# Altium schematic sheet MINIECO-ECO-ALM.SchDoc(MINIECO-ECO-ALM)
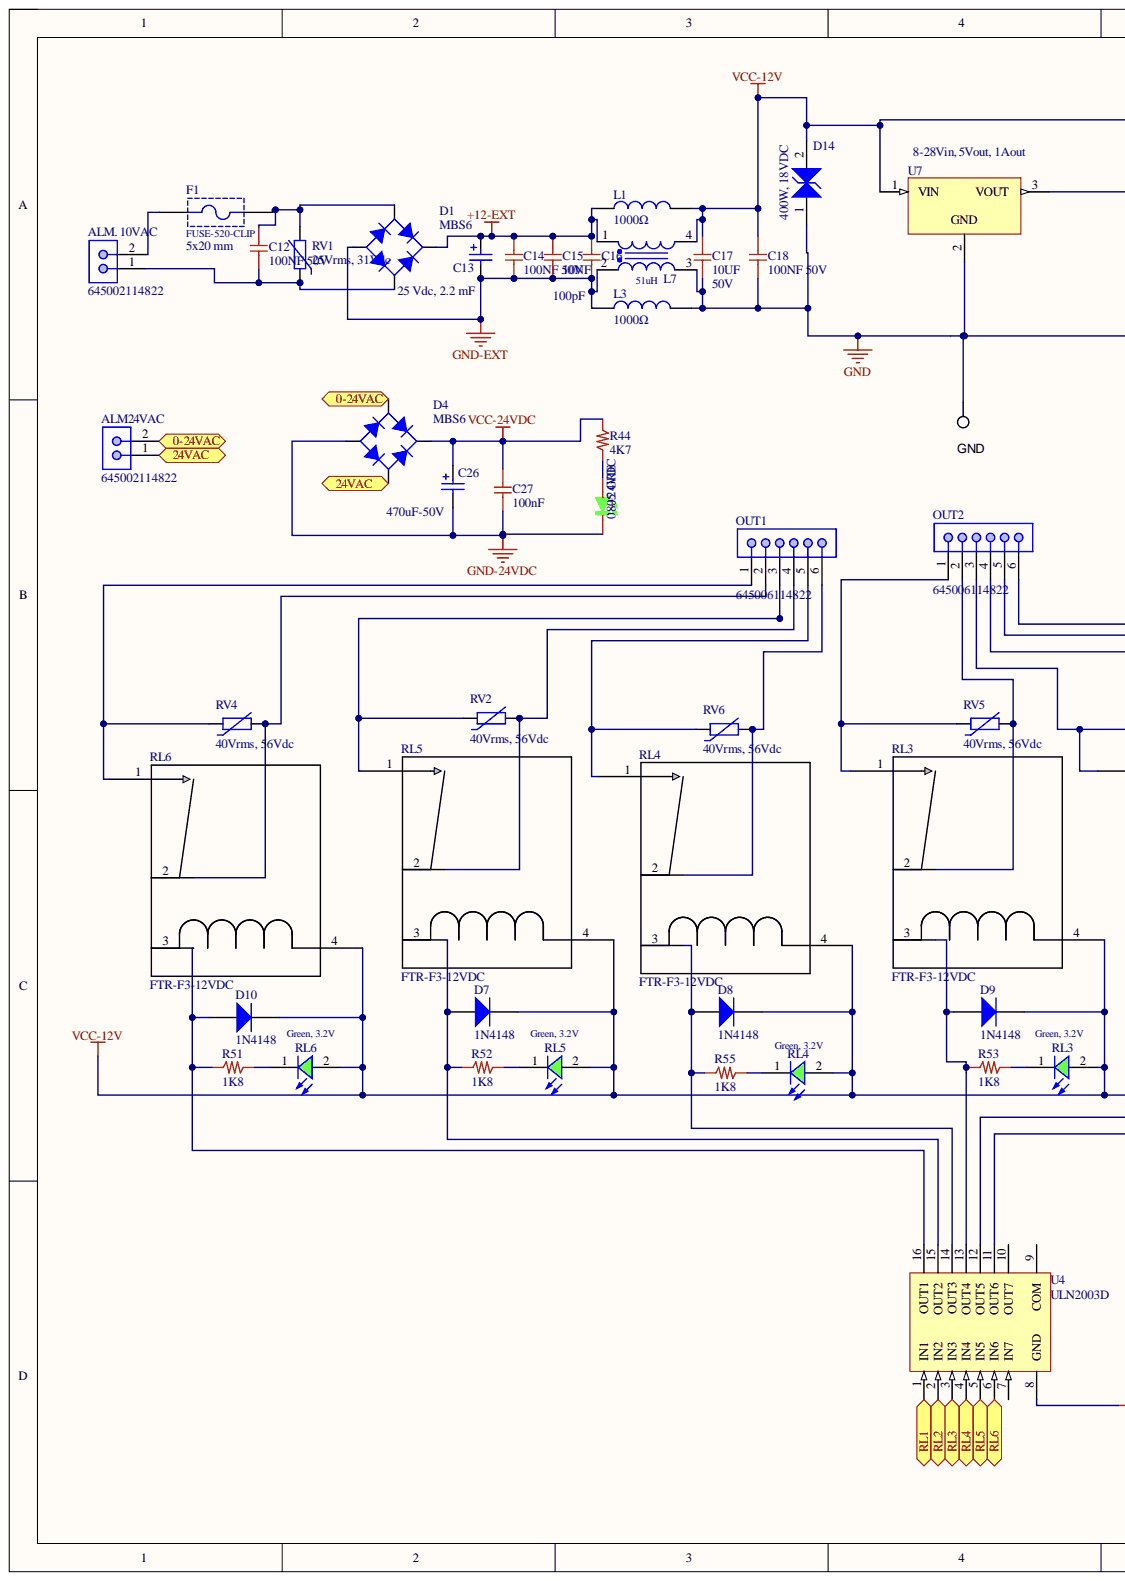
[diagram p0: MINIECO-ECO-ALM.SchDoc, left]
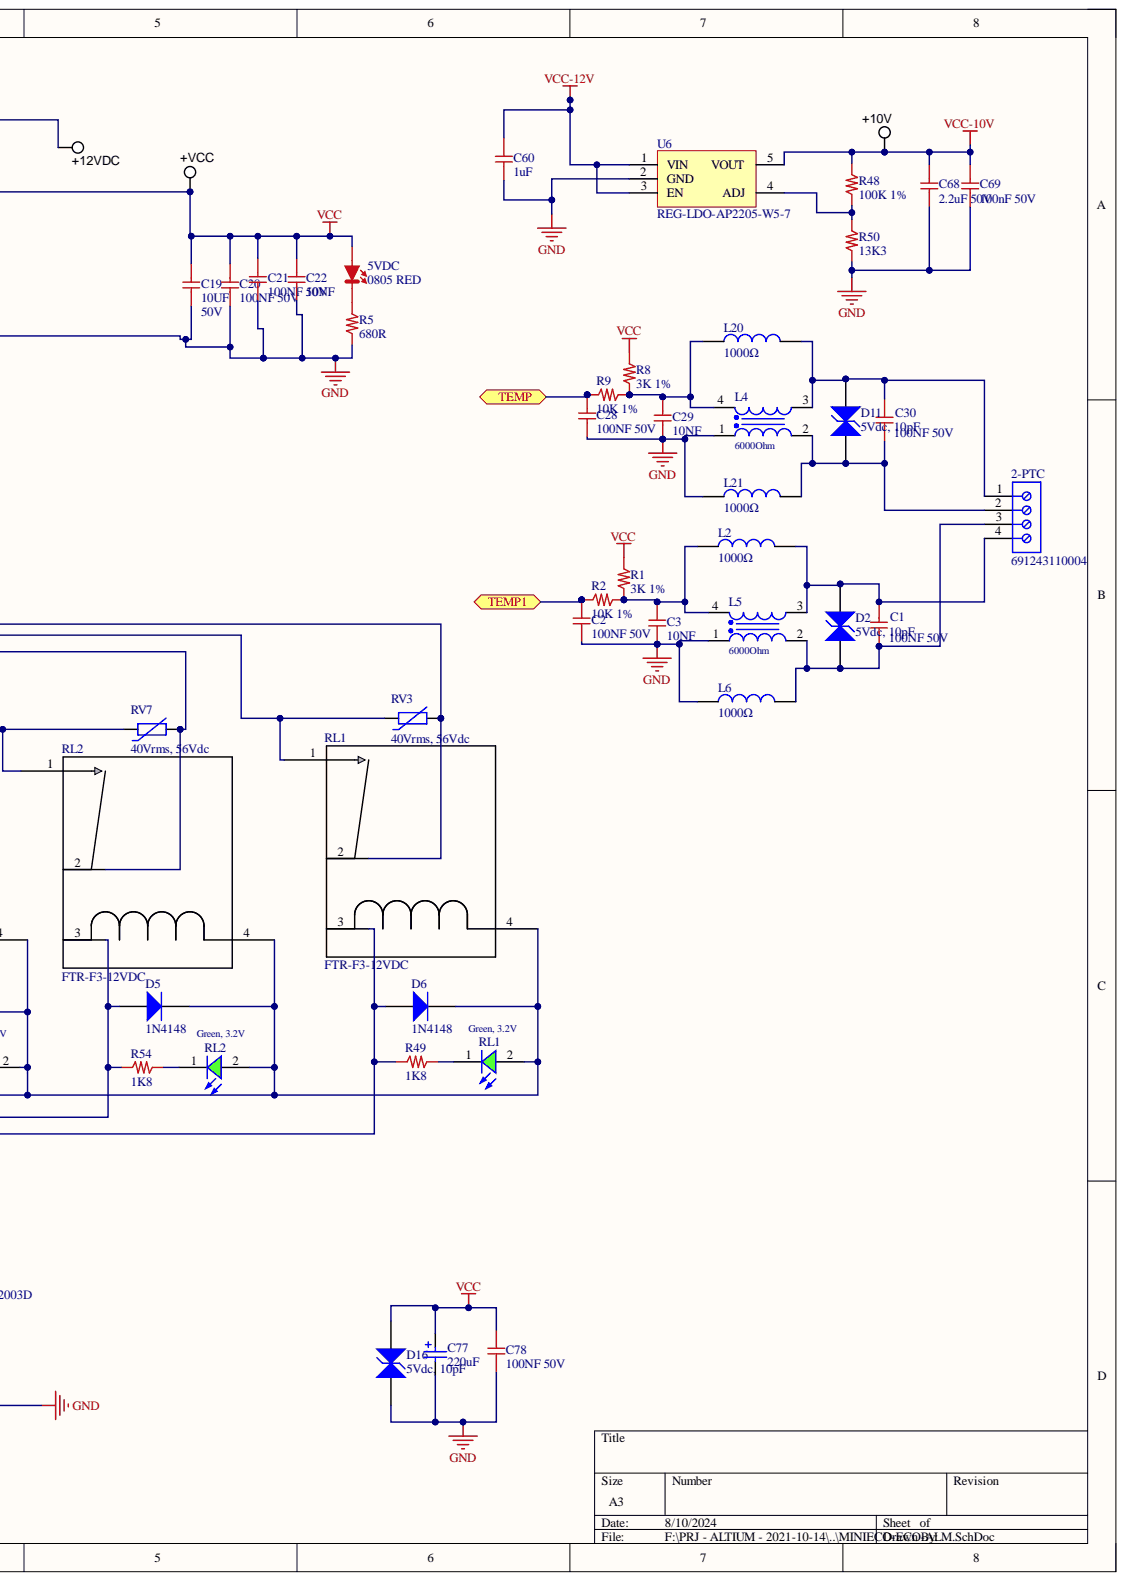
[diagram p1: MINIECO-ECO-ALM.SchDoc, right]

## Parts
2-PTC  691243110004  [691243110004]
5VDC  0805 RED  [0805_Red]
+10V  TEST POINT  [Keystone5012]  (value not drawn)
+12VDC  TEST POINT  [Keystone5012]  (value not drawn)
+24VDC  0805 GRE  [0805_Green]
+VCC  TEST POINT  [Keystone5012]  (value not drawn)
ALM24VAC  645002114822  [645002114822]
ALM._10VAC  645002114822  [645002114822]
C1  100NF 50V  [0603_c]
C2  100NF 50V  [0603_c]
C3  10NF  [0603_c]
C12  100NF 50V  [0603_c]
C13  25 Vdc, 2.2 mF  value 2.2 mF  [WCAP-ATLI_13x35x5]
C14  100NF 50V  [0603_c]
C15  10NF  [0603_c]
C16  100pF  [0603_c]
C17  10UF  Tensione: 50V  [1206_C]
C18  100NF 50V  [0603_c]
C19  10UF  Tensione: 50V  [1206_C]
C20  100NF 50V  [0603_c]
C21  100NF 50V  [0603_c]
C22  10NF  [0603_c]
C26  value 470uF-50V  [CAP-33F-3V8]  (hidden comment: CAP-470-50V-VERT)
C27  100nF  [0805_C]
C28  100NF 50V  [0603_c]
C29  10NF  [0603_c]
C30  100NF 50V  [0603_c]
C60  1uF  [0603_c]
C68  2.2uF 50V  [0805_C]
C69  100nF 50V  [0603_c]
C77  value 220uF  [WCAP-ASLL_10x10.5]  (hidden comment: 865060657012)
C78  100NF 50V  [0603_c]
D1  MBS6  [HD01-T SOP4]
D2  5Vdc, 10pF  [WE-VE_0603]
D4  MBS6  [HD01-T SOP4]
D5  1N4148  [1N4148]
D6  1N4148  [1N4148]
D7  1N4148  [1N4148]
D8  1N4148  [1N4148]
D9  1N4148  [1N4148]
D10  1N4148  [1N4148]
D11  5Vdc, 10pF  [WE-VE_0603]
D14  400W, 18VDC  [WE-TVSP_DO-214AC_Bidirectional]
D16  5Vdc, 10pF  [WE-VE_0603]
F1  FUSE-520-CLIP  Fuse Size: 5x20 mm  [FUSE-520-CLIP]
GND  TEST POINT  [Keystone5012]  (value not drawn)
L1  1000Ω  [WE-CBF_0805_Wide Band]
L2  1000Ω  [WE-CBF_0805_Wide Band]
L3  1000Ω  [WE-CBF_0805_Wide Band]
L4  6000Ohm  [WE-CNSW_1206]
L5  6000Ohm  [WE-CNSW_1206]
L6  1000Ω  [WE-CBF_0805_Wide Band]
L7  51uH  [WE-SL2]
L20  1000Ω  [WE-CBF_0805_Wide Band]
L21  1000Ω  [WE-CBF_0805_Wide Band]
OUT1  645006114822  [645006114822]
OUT2  645006114822  [645006114822]
R1  3K 1%  [0805_R]
R2  10K 1%  [0603_R]
R5  680R  [0603_R]
R8  3K 1%  [0805_R]
R9  10K 1%  [0603_R]
R44  4K7  [2512_R]
R48  100K 1%  [0603_R]
R49  1K8  [0805_R]
R50  13K3  [0603_R]
R51  1K8  [0805_R]
R52  1K8  [0805_R]
R53  1K8  [0805_R]
R54  1K8  [0805_R]
R55  1K8  [0805_R]
RL1  Green, 3.2V  Forward Voltage typ.: 3.2V  [WL-SMCW_0603_H=0.7mm]
RL1  FTR-F3-12VDC  [PAN_ALD]
RL2  Green, 3.2V  Forward Voltage typ.: 3.2V  [WL-SMCW_0603_H=0.7mm]
RL2  FTR-F3-12VDC  [PAN_ALD]
RL3  Green, 3.2V  Forward Voltage typ.: 3.2V  [WL-SMCW_0603_H=0.7mm]
RL3  FTR-F3-12VDC  [PAN_ALD]
RL4  Green, 3.2V  Forward Voltage typ.: 3.2V  [WL-SMCW_0603_H=0.7mm]
RL4  FTR-F3-12VDC  [PAN_ALD]
RL5  Green, 3.2V  Forward Voltage typ.: 3.2V  [WL-SMCW_0603_H=0.7mm]
RL5  FTR-F3-12VDC  [PAN_ALD]
RL6  Green, 3.2V  Forward Voltage typ.: 3.2V  [WL-SMCW_0603_H=0.7mm]
RL6  FTR-F3-12VDC  [PAN_ALD]
RV1  25Vrms, 31Vdc  [WE-VD_7mm_820572501]
RV2  40Vrms, 56Vdc  DC Operating Voltage: 56Vdc  [WE-VD_7mm_820574001]
RV3  40Vrms, 56Vdc  DC Operating Voltage: 56Vdc  [WE-VD_7mm_820574001]
RV4  40Vrms, 56Vdc  DC Operating Voltage: 56Vdc  [WE-VD_7mm_820574001]
RV5  40Vrms, 56Vdc  DC Operating Voltage: 56Vdc  [WE-VD_7mm_820574001]
RV6  40Vrms, 56Vdc  DC Operating Voltage: 56Vdc  [WE-VD_7mm_820574001]
RV7  40Vrms, 56Vdc  DC Operating Voltage: 56Vdc  [WE-VD_7mm_820574001]
U4  ULN2003D  [SO16_N]
U6  REG-LDO-AP2205-W5-7  [SOT-23-5_MC_MCH-M]
U7  8-28Vin, 5Vout, 1Aout  [MagI3C-FDSM_SIP-3_L11.6W8.5H10.5]

## Ports
0-24VAC, 24VAC, RL1, RL2, RL3, RL4, RL5, RL6, TEMP, TEMP1

## Nets
+12-EXT: C13.1 C14.1 C15.1 C16.1 D1.1 L1.1 L7.1
GND: C2.2 C3.2 C17.2 C18.2 C19.2 C20.2 C21.2 C22.2 C28.2 C29.2 C60.2 C68.2 C69.2 C77.2 C78.2 D14.1 D16.2 GND.1 L3.2 L4.1 L5.1 L6.1 L7.3 L21.1 R5.2 R50.2 U4.8(GND) U6.2(GND) U7.2
GND-24VDC: +24VDC.K C26.2 C27.2 D4.2
GND-EXT: C13.2 C14.2 C15.2 C16.2 D1.2 L3.1 L7.2
VCC: 5VDC.A +VCC.1 C19.1 C20.1 C21.1 C22.1 C77.1 C78.1 D16.1 R1.1 R8.1 U7.3
VCC-10V: +10V.1 C68.1 C69.1 R48.1 U6.5(VOUT)
VCC-12V: +12VDC.1 C17.1 C18.1 C60.1 D5.2 D6.2 D7.2 D8.2 D9.2 D10.2 D14.2 L1.2 L7.4 RL1.2 RL1.4 RL2.2 RL2.4 RL3.2 RL3.4 RL4.2 RL4.4 RL5.2 RL5.4 RL6.2 RL6.4 U6.1(VIN) U6.3(EN) U7.1
VCC-24VDC: C26.1 C27.1 D4.1 R44.1
0-24VAC: ALM24VAC.2 D4.4 ; port 0-24VAC
24VAC: ALM24VAC.1 D4.3 ; port 24VAC
RL1: U4.1(IN1) ; port RL1
RL2: U4.2(IN2) ; port RL2
RL3: U4.3(IN3) ; port RL3
RL4: U4.4(IN4) ; port RL4
RL5: U4.5(IN5) ; port RL5
RL6: U4.6(IN6) ; port RL6
TEMP: C28.1 R9.1 ; port TEMP
TEMP1: C2.1 R2.1 ; port TEMP1
Net2-PTC_1: 2-PTC.1 C30.2 D11.2 L4.3 L20.2
Net2-PTC_2: 2-PTC.2 C30.1 D11.1 L4.2 L21.2
Net2-PTC_3: 2-PTC.3 C1.1 D2.1 L5.2 L6.2
Net2-PTC_4: 2-PTC.4 C1.2 D2.2 L2.2 L5.3
Net5VDC_K: 5VDC.K R5.1
Net+24VDC_A: +24VDC.A R44.2
NetALM. 10VAC_1: ALM._10VAC.1 C12.2 D1.3 RV1.1
NetALM. 10VAC_2: ALM._10VAC.2 F1.1
NetC3_1: C3.1 L2.1 L5.4 R1.2 R2.2
NetC12_1: C12.1 D1.4 F1.2 RV1.2
NetC29_1: C29.1 L4.4 L20.1 R8.2 R9.2
NetD5_1: D5.1 R54.1 RL2.3 U4.12(OUT5)
NetD6_1: D6.1 R49.1 RL1.3 U4.11(OUT6)
NetD7_1: D7.1 R52.1 RL5.3 U4.15(OUT2)
NetD8_1: D8.1 R55.1 RL4.3 U4.14(OUT3)
NetD9_1: D9.1 R53.1 RL3.3 U4.13(OUT4)
NetD10_1: D10.1 R51.1 RL6.3 U4.16(OUT1)
NetOUT1_1: OUT1.1 RL6.1 RV4.1
NetOUT1_2: OUT1.2 RL6.2 RV4.2
NetOUT1_3: OUT1.3 RL5.1 RV2.1
NetOUT1_4: OUT1.4 RL5.2 RV2.2
NetOUT1_5: OUT1.5 RL4.1 RV6.1
NetOUT1_6: OUT1.6 RL4.2 RV6.2
NetOUT2_1: OUT2.1 RL3.1 RV5.1
NetOUT2_2: OUT2.2 RL3.2 RV5.2
NetOUT2_3: OUT2.3 RL2.1 RV7.1
NetOUT2_4: OUT2.4 RL2.2 RV7.2
NetOUT2_5: OUT2.5 RL1.1 RV3.1
NetOUT2_6: OUT2.6 RL1.2 RV3.2
NetR48_2: R48.2 R50.1 U6.4(ADJ)
NetR49_2: R49.2 RL1.1
NetR51_2: R51.2 RL6.1
NetR52_2: R52.2 RL5.1
NetR53_2: R53.2 RL3.1
NetR54_2: R54.2 RL2.1
NetR55_2: R55.2 RL4.1

Not connected: U4 7(IN7) 9(COM) 10(OUT7)

## Library data of the parts
2-PTC = 691243110004: WR-TBL Serie 2431 Horizontal Cable Entry, Rising Cage Clamp, THT, pitch 3.5mm, 4p; Manufacturer: Wurth Elektronik; Modularity: No; PCB/Cable/Panel: PCB; Pin Number: 4; Rated Current [UL]: 10A; Working Voltage [UL]: 300VAC; Application: Rising Cage Clamp; Component Kind: Standard; ComponentLink1Description: Manufacturer; ComponentLink1URL: http://www.we-online.de; ComponentLink2Description: Datasheet; ComponentLink2URL: https://katalog.we-online.de/em/datasheet/691243110004.pdf; Library Name: WR-TBL_Rising Cage Clamp.SchLib; Manufacturer Part Number: 691243110004; Mounting Technology: THT; Operating Temperature: -30 to +120degC; Packaging: Box; Pitch: 3.5mm; Published Date: Feburary 2021; Type: Horizontal
5VDC = LED_ROSSO_0805: LED Rosso 0805; Cod. Interno: LEDS 2AC080RS7500; Cod. Produttore: 150080RS75000; Luminosità: 150mcd; Produttore: WE; V: 2.0V; Component Kind: Standard; Library Name: DiodiLED.SchLib
+10V +12VDC +VCC GND = TP-HSW: Test Point, Multipurpose, White, TH; Manufacturer: Keystone; PartNumber: 5012; CompType: Test Point Loop; ContactFinish: Silver; Color: White; Component Kind: Standard; ComponentLink1Description: Datasheet URL; ComponentLink1URL: http://www.keyelco.com/userAssets/file/M60-2p60.pdf; LatestRevisionDate: 2017-01-19; Library Name: HSW.SchLib; MountType: TH; PackageReference: White Multipurpose Testpoint; RoHS: Y; Supplier 1: Digi-Key; Supplier 2: Mouser; Supplier Part Number 1: 36-5012-ND; Supplier Part Number 2: 534-5012; SymbolVersion: 2008-04-04; Publisher: Texas Instruments
+24VDC = LED_Verde_0805: LED Verde 0805; Cod. Interno: LEDS 9AC080GS7500; Cod. Produttore: 150080GS75000; Luminosità: 450mcd; Produttore: WE; V: 3.1V; Component Kind: Standard; Library Name: DiodiLED.SchLib
ALM24VAC ALM._10VAC = 645002114822: WR-WTB THT Male Vertical Locking Header, pitch 3.96mm, 2p; Contact Resistance: 20mOhm; Pin Number: 2; Rated Current: 7A; Withstanding Voltage: 1500VAC; Working Voltage: 250VAC; Component Kind: Standard; ComponentLink1Description: Manufacturer; ComponentLink1URL: http://www.we-online.de; ComponentLink2Description: Datasheet; ComponentLink2URL: https://www.we-online.com/catalog/datasheet/645002114822.pdf; Gender: Male; Library Name: WR-WTB_3.96mm.SchLib; Mounting Technology: THT; Operating Temperature: -40 to +105degC; Packaging: Bag; Part Number: 645002114822; Pitch: 3.96mm; Published Date: January 2020; Type: Vertical; Publisher: Wurth Elektronik
C1 C2 C12 C14 C18 C20 C21 C28 C30 C78 = 100NF 50V: Cap X7R 100nF 50V Flex Terminal; Cod. Interno: COCS50665050104; Cod. Produttore: CL10B104KB8SFNC; Produttore: Samsung; Cod. DigiKey: 1276-1936-2-ND; Component Kind: Standard; Library Name: CapSMD0603.SchLib
C3 C15 C22 C29 = 10NF 50V: Cap X7R 10nF 50V; Cod. Interno: COCS 556655000103; Cod. Produttore: CL05B103KB5NNNC; Produttore: Samsung; Cod. DigiKey: 1276-1028-2-ND; Component Kind: Standard; Library Name: CapSMD0603.SchLib
C13 = 860080478024: WCAP-ATLI Aluminum Electrolytic Capacitors, 13x35mm, 25 Vdc, 2.2 mF; Rated Voltage: 25 Vdc; Value: 2.2 mF; Component Kind: Standard; ComponentLink1Description: Manufacturer; ComponentLink1URL: http://www.we-online.de; ComponentLink2Description: Datasheet; ComponentLink2URL: http://katalog.we-online.com/pbs/datasheet/860080478024.pdf; Library Name: WCAP-ATLI.SchLib; Life Cycle: 5000h; Operating Temperature: -55 °C up to +105 °C; Part Number: 860080478024; Published Date: Sep. 2017; Size: 13x35mm; Publisher: Wurth Elektronik
C16 = 100pF 50V: Cap X7R 100pF 50V; Cod. Interno: COCS??; Cod. Produttore: ??; Component Kind: Standard; Library Name: CapSMD0603.SchLib; Produttore: ??
C17 C19 = 10UF 50V: Cap X5R 10UF 50V; Cod. Produttore: GRM31CR61H106KA12L; Produttore: MURATA; Cod. Farnell: 2666583; Component Kind: Standard; Library Name: CapSMD1206.SchLib; Temperature Range: Da -55°C a +125°C
C26 = CAP-470-50V-VERT: SUPERCAP 470-50V VERTICALE-10X21mm; Part Number: 865060457009; Rated Voltage: 25V DC; Component Kind: Standard; ComponentLink1Description: Manufacturer; ComponentLink1URL: http://www.we-online.de; ComponentLink2Description: Datasheet; ComponentLink2URL: http://katalog.we-online.de/pbs/datasheet/865060457009.pdf; Life Cycle: 5000h; Operating Temperature: -55 °C up to +105 °C; Published Date: June 2017; Size: Ф10x10.5mm; Library Name: HSW.SchLib; Publisher: Wurth Elektronik
C27 = 100nF 100V: Cap X7R 100nF 100V; Cod. Interno: COCS 557051010104; Cod. Produttore: ??; Component Kind: Standard; Library Name: CapSMD0805.SchLib; Produttore: ??
C60 = 1uF 10V: 1µF, 10 V, ± 10%, X5R 0603; Cod. Interno: COCS??; Cod. Produttore: 0603X225K100CT; Costo 100: 0,0436; Costo 1000: 0,0183; Produttore: WALSIN; Component Kind: Standard; ComponentLink1Description: FARNELL; ComponentLink1URL: http://it.farnell.com/walsin/0603x225k100ct/cond-mlcc-x5r-2-2uf-10v-0603-bob/dp/2495422?st=2.2uf; Library Name: CapSMD0603.SchLib
C68 = 2.2uF 50V: Cap 0805 X7R 2.2uF 50V; Component Kind: Standard; Library Name: CapSMD0805.SchLib; Temperature Range: Da -55°C a +125°C
C69 = 100nF 50V: Cap X7R 100nF 50V Flex Terminal; Cod. Interno: COCS50665050104; Cod. Produttore: CL10B104KB8SFNC; Produttore: Samsung; Cod. DigiKey: 1276-1936-2-ND; Component Kind: Standard; Library Name: CapSMD0603.SchLib
C77 = 865060657012: WCAP-ASLL Aluminum Electrolytic Capacitors, size Ф10x10.5mm, 50V DC, 220uF; Rated Voltage: 50V DC; Component Kind: Standard; ComponentLink1Description: Manufacturer; ComponentLink1URL: http://www.we-online.de; ComponentLink2Description: Datasheet; ComponentLink2URL: http://katalog.we-online.de/pbs/datasheet/865060657012.pdf; Library Name: WCAP-ASLL.SchLib; Life Cycle: 5000h; Operating Temperature: -55 °C up to +105 °C; Part Number: 865060657012; Published Date: June 2017; Size: Ф10x10.5mm; Publisher: Wurth Elektronik
D1 D4 = HD01-T: Full Wave Diode Bridge; Component Kind: Standard; Library Name: HSW.SchLib; Publisher: Altium Limited
D2 D11 D16 = 82356050100: WE-VE ESD Suppressor, size 0603, 5Vdc, 10pF; Clamp Voltage: 60V; ESD Voltage air discharge: 15kV; Leakage Current: 1uA; Capacitance: 10pF; Component Kind: Standard; ComponentLink1Description: Manufacturer; ComponentLink1URL: http://www.we-online.de; ComponentLink2Description: Datasheet; ComponentLink2URL: https://katalog.we-online.com/pbs/datasheet/82356050100.pdf; Library Name: WE-VE_0603.SchLib; Mounting Technology: SMT; Operating Temperature: -40 to +85degC; Packaging: Tape and Reel; Part Number: 82356050100; Published Date: June 2019; Size: 0603; Working Voltage: 5Vdc; Publisher: Wurth Elektronik
D5-D10 = 1N4148: High Conductance Fast Diode; Code_JEDEC: DO-204-AH; Component Kind: Standard; ComponentLink1Description: Manufacturer Link; ComponentLink1URL: http://www.fairchildsemi.com/; ComponentLink2Description: Datasheet; ComponentLink2URL: http://www.fairchildsemi.com/ds/1N%2F1N4148.pdf; DatasheetVersion: 1997; LatestRevisionDate: 17-Jul-2002; LatestRevisionNote: Re-released for DXP Platform.; PackageDescription: Diode, Axial; Body 3.8 x 1.9 mm (LxDia. max), Lead Dia. 0.53 mm (max); PackageReference: DO-35; Published: 8-Jun-2000; Library Name: HSW.SchLib; Publisher: Altium Limited
D14 = 824501181: WE-TVSP Power TVS Diode, DO-214AC, 400W, 18VDC, Bidirectional; (Forward) Peak Pulse Current: 13.7A; (Reverse) Breakdown Voltage: 21.05V; Clamping Voltage: 29.2V; DC Operating Voltage: 18V; Component Kind: Standard; ComponentLink1Description: Manufacturer; ComponentLink1URL: http://www.we-online.de; ComponentLink2Description: Datasheet; ComponentLink2URL: https://katalog.we-online.com/pbs/datasheet/824501181.pdf; Library Name: WE-TVSP_DO-214AC_Bidirectional.SchLib; Mounting Technology: SMT; Operating Temperature: -65 to +150degC; Packaging: Tape and Reel; Part Number: 824501181; Polarity: Bidirectional; Published Date: March 2019; Size: DO-214AC; Steady State Power Dissipation: 400W; Publisher: Wurth Elektronik
F1 = FUSE-520-CLIP: LITTELFUSE-520 Series Circuit Board Mount Fuse Clips for 5mm & 6.3mm Diameter Fuses; Part Number: 696108003002; Component Kind: Standard; ComponentLink1Description: Manufacturer; ComponentLink1URL: http://www.we-online.de; ComponentLink2Description: Datasheet; ComponentLink2URL: https://katalog.we-online.de/em/datasheet/696108003002.pdf; Mounting Technology: THT; Operating Temperature: -30 to 120 degC; Packaging: Box; Published Date: August 2018; Library Name: HSW.SchLib; Publisher: Wurth Elektronik
L1-L3 L6 L20 L21 = WE-CBF, 74279205: WE-CBF SMD EMI Suppression Ferrite Bead, 0805, 1000Ω; Type: Wide Band; Component Kind: Standard; ComponentLink1Description: Manufacturer; ComponentLink1URL: http://www.we-online.de; ComponentLink2Description: Datasheet; ComponentLink2URL: http://katalog.we-online.de/pbs/datasheet/74279205.pdf; Library Name: WE-CBF_0805.SchLib; PartNumber: 74279205; PublishedDate: Aug 2016; Size: 0805; Value: 1000Ω; Publisher: Wurth Elektronik
L4 L5 = 744232101: WE-CNSW SMT Common Mode Line Filter, size 1206, 6000Ohm, 0.09A, 50V; Manufacturer: Wurth Elektronik; DC Resistance max.: 5.5Ohm; Impedance Test Condition: 100MHz; Insulation Test Voltage: 125VAC; Winding Style: bifiliar; Component Kind: Standard; ComponentLink1Description: Manufacturer; ComponentLink1URL: http://www.we-online.de; ComponentLink2Description: Datasheet; ComponentLink2URL: https://www.we-online.com/catalog/datasheet/744232101.pdf; Impedance: 6000Ohm; Library Name: WE-CNSW_1206.SchLib; Manufacturer Part Number: 744232101; Mounting Technology: SMT; Operating Temperature: -40 to +125degC; Packaging: Tape and Reel; Published Date: April 2020; Rated Current: 0.09A; Rated Voltage: 50V; Size: 1206
L7 = 744227S: WE-SL2 SMT Common Mode Line Filter, 51uH, 1000mA, 80V; DC Resistance max.: 0.16Ohm; Impedance max.: 5500Ohm; Insulation Test Voltage: 500V (AC); Leakage Inductance: 3150nH; Winding Style: sectional; Component Kind: Standard; ComponentLink1Description: Manufacturer; ComponentLink1URL: http://www.we-online.de; ComponentLink2Description: Datasheet; ComponentLink2URL: https://katalog.we-online.com/pbs/datasheet/744227S.pdf; Inductance: 51uH; Library Name: WE-SL2.SchLib; Mounting Technology: SMT; Operating Temperature: -40 to +125degC; Packaging: Tape and Reel; Part Number: 744227S; Published Date: March 2019; Rated Current: 1000mA; Rated Voltage: 80V; Publisher: Wurth Elektronik
OUT1 OUT2 = 645006114822: WR-WTB THT Male Vertical Locking Header, pitch 3.96mm, 6p; Contact Resistance: 20mOhm; Pin Number: 6; Rated Current: 7A; Withstanding Voltage: 1500VAC; Working Voltage: 250VAC; Component Kind: Standard; ComponentLink1Description: Manufacturer; ComponentLink1URL: http://www.we-online.de; ComponentLink2Description: Datasheet; ComponentLink2URL: https://www.we-online.com/catalog/datasheet/645006114822.pdf; Gender: Male; Library Name: WR-WTB_3.96mm.SchLib; Mounting Technology: THT; Operating Temperature: -40 to +105degC; Packaging: Bag; Part Number: 645006114822; Pitch: 3.96mm; Published Date: January 2020; Type: Vertical; Publisher: Wurth Elektronik
R1 R8 = 3K 1%: Res Film Spesso 0805 1/2W 3K 1%; Cod. Interno: ??; Component Kind: Standard; Library Name: ResSMD0805.SchLib
R2 R9 = 10K 1%: Res Film Spesso 1/16W 10K 1%; Cod. Interno: RESS4166356B0103; Cod. Produttore: ERJ-3EKF1002V; Produttore: Panasonic; Component Kind: Standard; Library Name: ResSMD0603.SchLib
R5 = 680R: Res Film Spesso 1/16W 680R 5%; Cod. Interno: RESS 4166556B0681; Component Kind: Standard; Library Name: ResSMD0603.SchLib
R44 = 4K7: Precision Thick Film 4K7 1W 1%; Cod. Interno: RESS 4190310A54R9; Cod. Produttore: ERJ-1TNF54R9U; Produttore: Vishay Dale; Cod. DigiKey: PT54.9AFTR-ND; Component Kind: Standard; Library Name: ResSMD2512.SchLib
R48 = 100K 1%: Res Film Spesso 1/16W 100K 1%; Cod. Interno: RESS4166360C0104; Cod. Produttore: ??; Component Kind: Standard; Library Name: ResSMD0603.SchLib; Produttore: ??
R49 R51-R55 = 1K8: Res Film Spesso 1/2W 1K8 5%; Cod. Interno: RESS4170555B0182??; Potenza: 1/2W 5%; Component Kind: Standard; Library Name: ResSMD0805.SchLib
R50 = 13K3: Res Film Spesso 1/16W 13K3  1%; Cod. Produttore: ??; Component Kind: Standard; Library Name: ResSMD0603.SchLib; Produttore: ??
RL1-RL6 = 150060GS75000: WL-SMCW SMT Mono-color Chip LED Waterclear, size 0603, Green, 3.2V, 140deg; Chip Technology: InGaN; Dominant Wavelength typ.: 525nm; Luminous Intensity typ.: 430mcd; Peak Wavelength typ.: 515nm; Component Kind: Standard; ComponentLink1Description: Manufacturer; ComponentLink1URL: http://www.we-online.de; ComponentLink2Description: Datasheet; ComponentLink2URL: https://www.we-online.com/catalog/datasheet/150060GS75000.pdf; Emitting Color: Green; Library Name: WL-SMCW_0603.SchLib; Mounting Technology: SMT; Operating Temperature: -40 to +85degC; Packaging: Tape and Reel; Part Number: 150060GS75000; Published Date: December 2019; Size: 0603; Viewing Angle: 140deg; Publisher: Wurth Elektronik
RL1-RL6 = FTR-F3-12VDC: RELE NA 5A 250VAC COIL12VDC; Manufacturer_Part_Number: ALDP106W; Built_By: EMA_UL_Team; Vendor: Panasonic; Component Kind: Standard; Library Name: FTR-F3.SchLib; RefDes: RefDes; Type: DEV; Value: Value; Copyright: Copyright (C) 2018 Accelerated Designs. All rights reserved
RV1 = 820572501: WE-VD Disk Varistor, size 7mm, 25Vrms, 31Vdc; (Channel) Input Capacitance: 1820pF; (Reverse) Breakdown Voltage: 39V; (Reverse) Peak Pulse Current: 250A; Clamping Voltage: 77V; Energy Absorbtion: 2.4J; Power Dissipation: 0.02W; AC Operating Voltage: 25Vrms; Component Kind: Standard; ComponentLink1Description: Manufacturer; ComponentLink1URL: http://www.we-online.de; ComponentLink2Description: Datasheet; ComponentLink2URL: https://katalog.we-online.com/pbs/datasheet/820572501.pdf; DC Operating Voltage: 31Vdc; Mounting Technology: THT; Operating Temperature: -40 to +85degC; Packaging: Tape and Reel; Part Number: 820572501; Published Date: March 2019; Size: 7mm; Library Name: MOV_7mm.SchLib; Publisher: Wurth Elektronik
RV2-RV7 = 820574001: WE-VD Disk Varistor, size 7mm, 40Vrms, 56Vdc; (Channel) Input Capacitance: 1120pF; (Reverse) Breakdown Voltage: 68V; (Reverse) Peak Pulse Current: 250A; Clamping Voltage: 135V; Energy Absorbtion: 4.3J; Power Dissipation: 0.02W; AC Operating Voltage: 40Vrms; Component Kind: Standard; ComponentLink1Description: Manufacturer; ComponentLink1URL: http://www.we-online.de; ComponentLink2Description: Datasheet; ComponentLink2URL: https://katalog.we-online.com/pbs/datasheet/820574001.pdf; Mounting Technology: THT; Operating Temperature: -40 to +85degC; Packaging: Tape and Reel; Part Number: 820574001; Published Date: March 2019; Size: 7mm; Library Name: MOV_7mm.SchLib; Publisher: Wurth Elektronik
U4 = ULN2003D: Seven Darlington Array; Code_IPC: SOIC127P600-16; Component Kind: Standard; ComponentLink1Description: Manufacturer Link; ComponentLink1URL: http://www.st.com/; ComponentLink2Description: Datasheet; ComponentLink2URL: http://www.st.com/stonline/products/literature/ds/5279/uln2001.pdf; DatasheetVersion: Sep-1998; LatestRevisionDate: 13-Apr-2006; LatestRevisionNote: IPC-7351 Footprint Added.; PackageDescription: 16-Pin Small Outline Integrated Circuit 1.27 mm Pitch; PackageReference: SO16; PackageVersion: May-1998; Published: 8-Jun-2000; Library Name: HSW.SchLib; Publisher: Altium Limited
U6 = AP2205-W5-7: Linear Voltage Regulator IC Positive Adjustable 1 Output 250mA SOT-25; Component Kind: Standard; Library Name: HSW.SchLib
U7 = 173010578: MagI3C-FDSM Fixed Step Down Regulator Module, SIP-3, 8-28Vin, 5Vout, 1Aout; Manufacturer: Wurth Elektronik; Input Voltage max.: 28V; Input Voltage min.: 8V; Output Current: 1A; Output Voltage: 5V; Component Kind: Standard; ComponentLink1Description: Manufacturer Link; ComponentLink1URL: http://www.we-online.de; ComponentLink2Description: Datasheet; ComponentLink2URL: https://www.we-online.com/catalog/datasheet/173010578.pdf; Height: 10.5mm; Length: 11.6mm; Library Name: MagI3C-FDSM.SchLib; Manufacturer Part Number: 173010578; Mounting Technology: THT; Operating Temperature max.: 85C; Operating Temperature min.: -40C; Packaging: Tube; Size: SIP-3; Width: 8.5mm
